# Altium schematic sheet ETH_M_V1.SchDoc
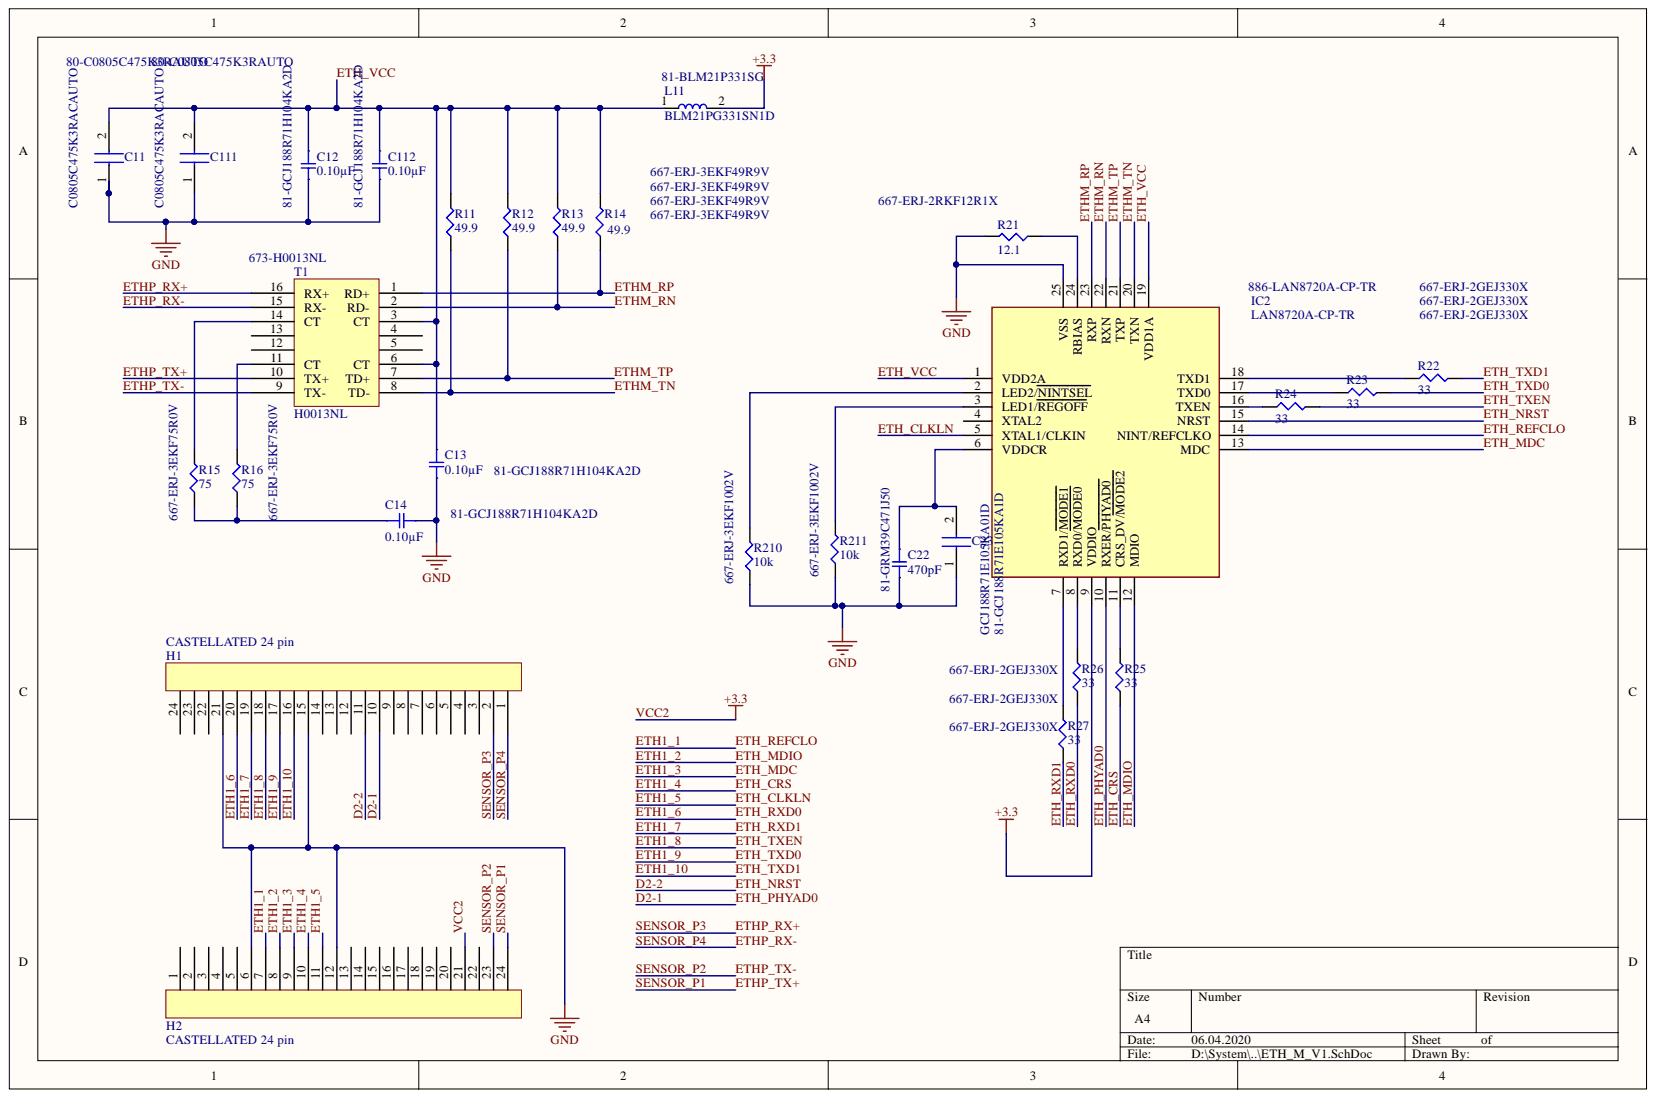
[diagram p0: ETH_M_V1.SchDoc]

## Parts
C11  C0805C475K3RACAUTO  [CAPC2012X125N]
C12  0.10µF  Supplier Part Number 1: 81-GCJ188R71H104KA2D  [SMD-0603C]
C13  0.10µF  [SMD-0603C]
C14  0.10µF  [SMD-0603C]
C22  470pF  Supplier Part Number 1: 81-GRM39C471J50  [SMD-0603C]
C23  GCJ188R71E105KA01D  Supplier Part Number 1: 81-GCJ188R71E105KA1D  [CAPC1608X100N]
C111  C0805C475K3RACAUTO  [CAPC2012X125N]
C112  0.10µF  Supplier Part Number 1: 81-GCJ188R71H104KA2D  [SMD-0603C]
H1  CASTELLATED 24 pin  [CASTELLATED 24 pin]
H2  CASTELLATED 24 pin  [CASTELLATED 24 pin]
IC2  LAN8720A-CP-TR  Mouser2 Part Number: 886-LAN8720A-CP-TR  [QFN50P400X400X100-25N-D]
L11  BLM21PG331SN1D  Supplier Part Number 1: 81-BLM21P331SG  [INDC2012X87]
R11  49.9  [SMD-0603-RES]
R12  49.9  [SMD-0603-RES]
R13  49.9  [SMD-0603-RES]
R14  49.9  [SMD-0603-RES]
R15  75  Supplier Part Number 1: 667-ERJ-3EKF75R0V  [SMD-0603-RES]
R16  75  [SMD-0603-RES]
R21  12.1  Supplier Part Number 1: 667-ERJ-2RKF12R1X  [SMD-0402-RES]
R22  33  [SMD-0402-RES]
R23  33  [SMD-0402-RES]
R24  33  [SMD-0402-RES]
R25  33  [SMD-0402-RES]
R26  33  Supplier Part Number 1: 667-ERJ-2GEJ330X  [SMD-0402-RES]
R27  33  Supplier Part Number 1: 667-ERJ-2GEJ330X  [SMD-0402-RES]
R210  10k  Supplier Part Number 1: 667-ERJ-3EKF1002V  [SMD-0603-RES]
R211  10k  Supplier Part Number 1: 667-ERJ-3EKF1002V  [SMD-0603-RES]
T1  H0013NL  Supplier Part Number 1: 673-H0013NL  [H0013NL]

## Nets
GND: C11.1 C12.2 C13.2 C14.2 C22.2 C23.1 C111.1 C112.2 H1.15 H1.21 H2.6 H2.12 IC2.25(VSS) R21.2 R210.1 R211.1
D2-1: H1.10 IC2.10(RXER/PHYAD0)
D2-2: H1.11 IC2.15(NRST)
ETH1_1: H2.7 IC2.14(NINT/REFCLKO)
ETH1_2: H2.8 IC2.12(MDIO)
ETH1_3: H2.9 IC2.13(MDC)
ETH1_4: H2.10 R25.1
ETH1_5: H2.11 IC2.5(XTAL1/CLKIN)
ETH1_6: H1.20 R26.1
ETH1_7: H1.19 R27.1
ETH1_8: H1.18 R24.2
ETH1_9: H1.17 R23.2
ETH1_10: H1.16 R22.2
ETH_VCC: C11.2 C12.1 C13.1 C111.2 C112.1 IC2.1(VDD2A) IC2.19(VDD1A) L11.1 R11.2 R12.2 R13.2 R14.2 T1.3(CT) T1.6(CT)
ETHM_RN: IC2.22(RXN) R13.1 T1.2(RD-)
ETHM_RP: IC2.23(RXP) R14.1 T1.1(RD+)
ETHM_TN: IC2.20(TXN) R11.1 T1.8(TD-)
ETHM_TP: IC2.21(TXP) R12.1 T1.7(TD+)
ETHP_RX+: H1.2 T1.16(RX+)
ETHP_RX-: H1.1 T1.15(RX-)
ETHP_TX+: H2.24 T1.10(TX+)
ETHP_TX-: H2.23 T1.9(TX-)
VCC2: H2.21 IC2.9(VDDIO) L11.2
NetC14_1: C14.1 R15.1 R16.1
NetC22_1: C22.1 C23.2 IC2.6(VDDCR)
NetIC2_2: IC2.2(LED2/NINTSEL) R210.2
NetIC2_3: IC2.3(LED1/REGOFF) R211.2
NetIC2_7: IC2.7(RXD1/MODE1) R27.2
NetIC2_8: IC2.8(RXD0/MODE0) R26.2
NetIC2_11: IC2.11(CRS_DV/MODE2) R25.2
NetIC2_16: IC2.16(TXEN) R24.1
NetIC2_17: IC2.17(TXD0) R23.1
NetIC2_18: IC2.18(TXD1) R22.1
NetIC2_24: IC2.24(RBIAS) R21.1
NetR15_2: R15.2 T1.14(CT)
NetR16_2: R16.2 T1.11(CT)

Not connected: H1 3 4 5 6 7 8 9 12 13 14 22 23 24; H2 1 2 3 4 5 13 14 15 16 17 18 19 20 22; IC2 4(XTAL2); T1 4 5 12 13

## Library data of the parts
C11 C111 = C0805C475K3RACAUTO: Capacitor; Manufacturer_Name: Kemet; Component Kind: Standard; Datasheet Link: https://componentsearchengine.com/Datasheets/1/C0805C475K3RACAUTO.pdf; Height: 1.25mm; Manufacturer_Part_Number: C0805C475K3RACAUTO; Mouser2 Part Number: 80-C0805C475K3RAUTO; Mouser2 Price/Stock: https://www.mouser.com/Search/Refine.aspx?Keyword=80-C0805C475K3RAUTO; Supplier 1: Mouser; Supplier Part Number 1: 80-C0805C475K3RAUTO
C12-C14 C112 = GCJ188R71H104KA12D: CAP CER 0.1UF 50V X7R 0603; Manufacturer: Murata Electronics North America; @ qty: 0; Minimum Quantity: 1; Tolerance: ±10%; Unit Price (USD): 0.12; Applications: Automotive, Boardflex Sensitive; Component Kind: Standard; Datasheets: http://www.murata.com/~/media/webrenewal/support/library/catalog/products/capacitor/mlcc/c03e.ashx; Digi-Key Part Number: 490-5798-1-ND; Factory Stock: 0; Features: Soft Termination; Height - Seated (Max): -; Image: //media.digikey.com/Renders/Murata%20Renders/0603%28LQG18%29.jpg; Lead Spacing: -; Lead Style: -; Library Name: capacitor_murata_0603.IntLib; Mounting Type: Surface Mount, MLCC; Operating Temperature: -55°C ~ 125°C; Package / Case: 0603 (1608 Metric); Packaging: Cut Tape (CT); Part Number: GCJ188R71H104KA12D; Part Status: Active; Quantity Available: 163695; Ratings: AEC-Q200; Series: GCJ; Size / Dimension: 0.063" L x 0.031" W (1.60mm x 0.80mm); Supplier 1: Mouser; Temperature Coefficient: X7R; Thickness (Max): 0.035" (0.90mm); Value: 0.10µF; Voltage: 50V
  C13 C14: Supplier Part Number 1: 81-GCJ188R71H104KA2D
C22 = GRM1885C1H471JA01D: CAP CER 470PF 50V NP0 0603; Manufacturer: Murata Electronics North America; @ qty: 0; Minimum Quantity: 1; Temperature Coefficient: C0G, NP0; Tolerance: ±5%; Unit Price (USD): 0.11; Applications: General Purpose; Component Kind: Standard; Datasheets: http://www.murata.com/~/media/webrenewal/support/library/catalog/products/capacitor/mlcc/c02e.pdf; Digi-Key Part Number: 490-1443-1-ND; Factory Stock: 0; Features: -; Height - Seated (Max): -; Image: //media.digikey.com/Renders/Murata%20Renders/ZRB-SERIES-0402.jpg; Lead Spacing: -; Lead Style: -; Library Name: capacitor_murata_0603.IntLib; Mounting Type: Surface Mount, MLCC; Operating Temperature: -55°C ~ 125°C; Package / Case: 0603 (1608 Metric); Packaging: Cut Tape (CT); Part Number: GRM1885C1H471JA01D; Part Status: Active; Quantity Available: 472909; Ratings: -; Series: GRM; Size / Dimension: 0.063" L x 0.031" W (1.60mm x 0.80mm); Supplier 1: Mouser; Thickness (Max): 0.035" (0.90mm); Value: 470pF; Voltage: 50V
C23 = GCJ188R71E105KA01D: Capacitor; Manufacturer_Name: Murata Electronics; Component Kind: Standard; Datasheet Link: https://psearch.en.murata.com/capacitor/product/GCJ188R71E105KA01%23.html; Height: 1mm; Manufacturer_Part_Number: GCJ188R71E105KA01D; Supplier 1: Mouser
IC2 = LAN8720A-CP-TR: Integrated Circuit; Manufacturer_Name: Microchip; Component Kind: Standard; Datasheet Link: https://componentsearchengine.com/Datasheets/1/LAN8720A-CP-TR.pdf; Height: 1mm; Manufacturer_Part_Number: LAN8720A-CP-TR; Mouser2 Price/Stock: https://www.mouser.com/Search/Refine.aspx?Keyword=886-LAN8720A-CP-TR; Supplier 1: Mouser; Supplier Part Number 1: 886-LAN8720A-CP-TR
L11 = BLM21PG331SN1D: Ferrite Beads Multi-Layer 330Ohm 25% 100MHz 1.5A 70mOhm DCR 0805 Paper T/R; MF: Murata; Component Kind: Standard; Digi-Key_Part_Number: 490-5988-1-ND; Digi-Key_Purchase_URL: https://www.digikey.ru/product-detail/en/murata-electronics-north-america/BLM21PG331SN1D/490-5988-1-ND/3845188?utm_source=snapeda&utm_medium=aggregator&utm_campaign=symbol; Library Name: BLM21PG331SN1D.SchLib; MP: BLM21PG331SN1D; Package: 0805 Murata; SnapEDA_Link: https://www.snapeda.com/parts/BLM21PG331SN1D/Murata%20Electronics%20North%20America/view-part/?ref=snap; Supplier 1: Mouser
R11-R14 = ERJ-3EKF49R9V: RES SMD 49.9 OHM 1% 1/10W 0603; Manufacturer: Panasonic Electronic Components; @ qty: 1500; Factory Stock: 0; Minimum Quantity: 1; Power (Watts): 0.1W, 1/10W; Temperature Coefficient: ±100ppm/°C; Unit Price (USD): 0.00379; Component Kind: Standard; Composition: Thick Film; Datasheets: http://industrial.panasonic.com/www-cgi/jvcr13pz.cgi?E+PZ+3+AOA0002+ERJ3EKF49R9V+7+WW; Digi-Key Part Number: P49.9HCT-ND; Failure Rate: -; Features: Automotive AEC-Q200; Height - Seated (Max): 0.022" (0.55mm); Image: //media.digikey.com/Photos/Panasonic%20Photos/MFG_ERJ-P6W%20Series.jpg; Library Name: Panasonic_ERJ_0603.IntLib; Number of Terminations: 2; Operating Temperature: -55°C ~ 155°C; Package / Case: 0603 (1608 Metric); Packaging: Cut Tape (CT); Part Number: ERJ-3EKF49R9V; Part Status: Active; Quantity Available: 566023; Series: ERJ; Size / Dimension: 0.063" L x 0.031" W (1.60mm x 0.80mm); Supplier 1: Mouser; Supplier Device Package: 0603; Supplier Part Number 1: 667-ERJ-3EKF49R9V; Tolerance: ±1%; Value: 49.9
R15 R16 = ERJ-3EKF75R0V: RES SMD 75 OHM 1% 1/10W 0603; Manufacturer: Panasonic Electronic Components; @ qty: 1500; Factory Stock: 0; Minimum Quantity: 1; Power (Watts): 0.1W, 1/10W; Temperature Coefficient: ±100ppm/°C; Unit Price (USD): 0.00379; Component Kind: Standard; Composition: Thick Film; Datasheets: http://industrial.panasonic.com/www-cgi/jvcr13pz.cgi?E+PZ+3+AOA0002+ERJ3EKF75R0V+7+WW; Digi-Key Part Number: P75.0HCT-ND; Failure Rate: -; Features: Automotive AEC-Q200; Height - Seated (Max): 0.022" (0.55mm); Image: //media.digikey.com/Photos/Panasonic%20Photos/MFG_ERJ-P6W%20Series.jpg; Library Name: Panasonic_ERJ_0603.IntLib; Number of Terminations: 2; Operating Temperature: -55°C ~ 155°C; Package / Case: 0603 (1608 Metric); Packaging: Cut Tape (CT); Part Number: ERJ-3EKF75R0V; Part Status: Active; Quantity Available: 236923; Series: ERJ; Size / Dimension: 0.063" L x 0.031" W (1.60mm x 0.80mm); Supplier 1: Mouser; Supplier Device Package: 0603; Tolerance: ±1%; Value: 75
  R16: Supplier Part Number 1: 667-ERJ-3EKF75R0V
R21 = ERJ-2RKF12R1X: RES SMD 12.1 OHM 1% 1/10W 0402; Manufacturer: Panasonic Electronic Components; @ qty: 1500; Factory Stock: 0; Minimum Quantity: 1; Power (Watts): 0.1W, 1/10W; Temperature Coefficient: ±100ppm/°C; Unit Price (USD): 0.00408; Component Kind: Standard; Composition: Thick Film; Datasheets: http://industrial.panasonic.com/www-cgi/jvcr13pz.cgi?E+PZ+3+AOA0002+ERJ2RKF12R1X+7+WW; Digi-Key Part Number: P12.1LCT-ND; Failure Rate: -; Features: Automotive AEC-Q200; Height - Seated (Max): 0.016" (0.40mm); Image: //media.digikey.com/Photos/Panasonic%20Photos/ERJ-PA2-Series.jpg; Library Name: Panasonic_ERJ_0402.IntLib; Number of Terminations: 2; Operating Temperature: -55°C ~ 155°C; Package / Case: 0402 (1005 Metric); Packaging: Cut Tape (CT); Part Number: ERJ-2RKF12R1X; Part Status: Active; Quantity Available: 33252; Series: ERJ; Size / Dimension: 0.039" L x 0.020" W (1.00mm x 0.50mm); Supplier 1: Mouser; Supplier Device Package: 0402; Tolerance: ±1%; Value: 12.1
R22-R27 = ERJ-2GEJ330X: RES SMD 33 OHM 5% 1/10W 0402; Manufacturer: Panasonic Electronic Components; @ qty: 1500; Factory Stock: 0; Minimum Quantity: 1; Power (Watts): 0.1W, 1/10W; Temperature Coefficient: ±200ppm/°C; Unit Price (USD): 0.00350; Component Kind: Standard; Composition: Thick Film; Datasheets: http://industrial.panasonic.com/www-cgi/jvcr13pz.cgi?E+PZ+3+AOA0001+ERJ2GEJ330X+7+WW; Digi-Key Part Number: P33JCT-ND; Failure Rate: -; Features: Automotive AEC-Q200; Height - Seated (Max): 0.016" (0.40mm); Image: //media.digikey.com/Photos/Panasonic%20Photos/ERJ-PA2-Series.jpg; Library Name: Panasonic_ERJ_0402.IntLib; Number of Terminations: 2; Operating Temperature: -55°C ~ 155°C; Package / Case: 0402 (1005 Metric); Packaging: Cut Tape (CT); Part Number: ERJ-2GEJ330X; Part Status: Active; Quantity Available: 857104; Series: ERJ; Size / Dimension: 0.039" L x 0.020" W (1.00mm x 0.50mm); Supplier 1: Mouser; Supplier Device Package: 0402; Tolerance: ±5%; Value: 33
  R22-R25: Supplier Part Number 1: 667-ERJ-2GEJ330X
R210 R211 = ERJ-3EKF1002V: RES SMD 10K OHM 1% 1/10W 0603; Manufacturer: Panasonic Electronic Components; @ qty: 1500; Factory Stock: 0; Minimum Quantity: 1; Power (Watts): 0.1W, 1/10W; Temperature Coefficient: ±100ppm/°C; Unit Price (USD): 0.00379; Component Kind: Standard; Composition: Thick Film; Datasheets: http://industrial.panasonic.com/www-cgi/jvcr13pz.cgi?E+PZ+3+AOA0002+ERJ3EKF1002V+7+WW; Digi-Key Part Number: P10.0KHCT-ND; Failure Rate: -; Features: Automotive AEC-Q200; Height - Seated (Max): 0.022" (0.55mm); Image: //media.digikey.com/Photos/Panasonic%20Photos/MFG_ERJ-P6W%20Series.jpg; Library Name: Panasonic_ERJ_0603.IntLib; Number of Terminations: 2; Operating Temperature: -55°C ~ 155°C; Package / Case: 0603 (1608 Metric); Packaging: Cut Tape (CT); Part Number: ERJ-3EKF1002V; Part Status: Active; Quantity Available: 6703141; Series: ERJ; Size / Dimension: 0.063" L x 0.031" W (1.60mm x 0.80mm); Supplier 1: Mouser; Supplier Device Package: 0603; Tolerance: ±1%; Value: 10k
T1 = H0013NL: Transformer; Manufacturer_Name: Pulse; Component Kind: Standard; Datasheet Link: https://productfinder.pulseeng.com/products/datasheets/H304.pdf; Height: 1mm; Library Name: SamacSys.SchLib; Manufacturer_Part_Number: H0013NL; Supplier 1: Mouser
H1 H2 = CASTELLATED 24 pin: Component Kind: Standard; Library Name: USIB.SchLib
